# Altium schematic sheet 1_Motor_And_Power.SchDoc
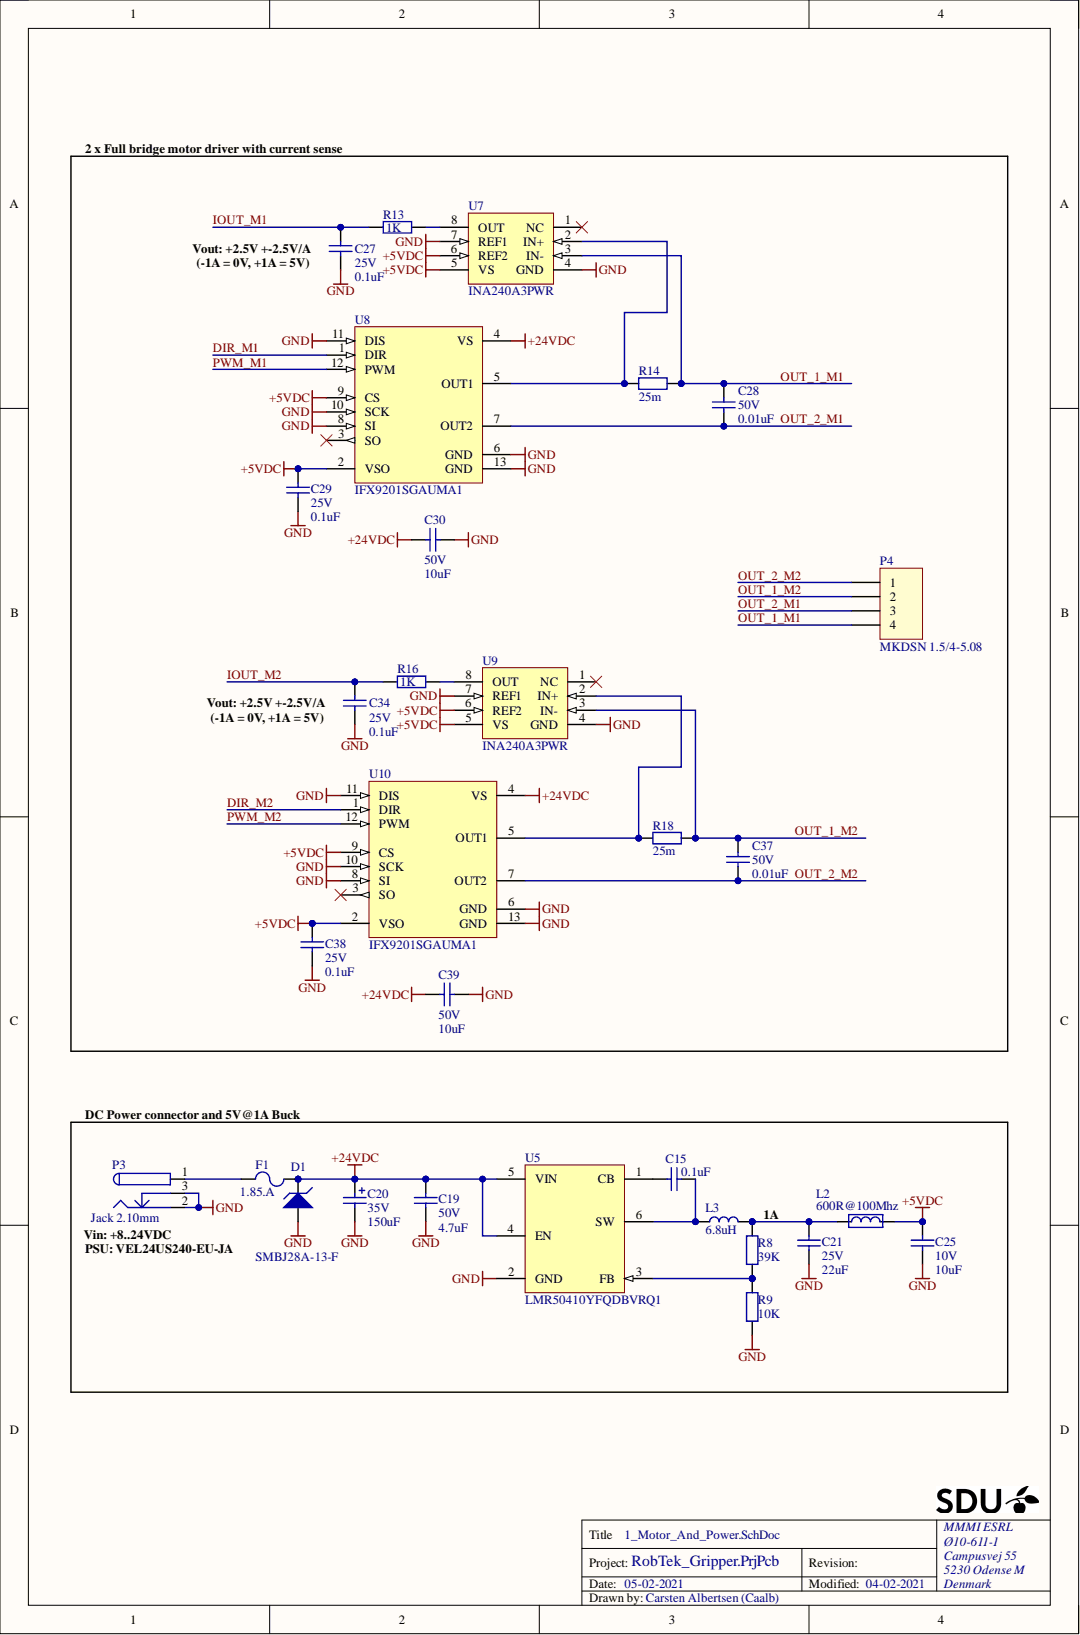
[diagram p0: 1_Motor_And_Power.SchDoc]

## Parts
C15  value 0.1uF  [0603C]  (hidden comment: 25V)
C19  50V  value 4.7uF  [1206C]
C20  value 150uF  Voltage: 35V  [ELYT SIZE F]  (hidden comment: PCR1V151MCL6GS)
C21  25V  value 22uF  [1210C_H]
C25  10V  value 10uF  [0805C]
C27  25V  value 0.1uF  [0603C]
C28  50V  value 0.01uF  [0603C]
C29  25V  value 0.1uF  [0603C]
C30  50V  value 10uF  [1210C_H]
C34  25V  value 0.1uF  [0603C]
C37  50V  value 0.01uF  [0603C]
C38  25V  value 0.1uF  [0603C]
C39  50V  value 10uF  [1210C_H]
D1  SMBJ28A-13-F  [DO-214AA]
F1  value 1.85.A  [2920F]  (hidden comment: 0ZCG0075BF2B)
L2  600R@100Mhz  [0805L]
L3  value 6.8uH  [PA4332]  (hidden comment: PA4332.682NLT)
P3  Jack 2.10mm  [694106301002]
P4  MKDSN 1.5/4-5.08  [MKDSN 1,5/4-5,08]
R8  1%  value 39K  [0603R]
R9  1%  value 10K  [0603R]
R13  1%  value 1K  [0603R]
R14  value 25m  [1206R]  (hidden comment: 1%)
R16  1%  value 1K  [0603R]
R18  value 25m  [1206R]  (hidden comment: 1%)
U5  LMR50410YFQDBVRQ1  [sot23-6]
U7  INA240A3PWR  [tssop8]
U8  IFX9201SGAUMA1  [PG-DSO-12-17]
U9  INA240A3PWR  [tssop8]
U10  IFX9201SGAUMA1  [PG-DSO-12-17]

## Nets
+5VDC: C25.2 C29.2 C38.2 L2.2 U7.5(VS) U7.6(REF2) U8.2(VSO) U8.9(CS) U9.5(VS) U9.6(REF2) U10.2(VSO) U10.9(CS)
+24VDC: C19.2 C20.1 C30.2 C39.2 D1.2 F1.2 U5.4(EN) U5.5(VIN) U8.4(VS) U10.4(VS)
GND: C19.1 C20.2 C21.1 C25.1 C27.1 C29.1 C30.1 C34.1 C38.1 C39.1 D1.1 P3.2 P3.3 R9.1 U5.2(GND) U7.4(GND) U7.7(REF1) U8.6(GND) U8.8(SI) U8.10(SCK) U8.11(DIS) U8.13(GND) U9.4(GND) U9.7(REF1) U10.6(GND) U10.8(SI) U10.10(SCK) U10.11(DIS) U10.13(GND)
DIR_M1: U8.1(DIR)
DIR_M2: U10.1(DIR)
IOUT_M1: C27.2 R13.2
IOUT_M2: C34.2 R16.2
OUT_1_M1: C28.2 P4.4 R14.2 U7.3(IN-)
OUT_1_M2: C37.2 P4.2 R18.2 U9.3(IN-)
OUT_2_M1: C28.1 P4.3 U8.7(OUT2)
OUT_2_M2: C37.1 P4.1 U10.7(OUT2)
PWM_M1: U8.12(PWM)
PWM_M2: U10.12(PWM)
NetC15_1: C15.1 L3.1 U5.6(SW)
NetC15_2: C15.2 U5.1(CB)
NetC21_2: C21.2 L2.1 L3.2 R8.2
NetF1_1: F1.1 P3.1
NetR8_1: R8.1 R9.2 U5.3(FB)
NetR13_1: R13.1 U7.8(OUT)
NetR14_1: R14.1 U7.2(IN+) U8.5(OUT1)
NetR16_1: R16.1 U9.8(OUT)
NetR18_1: R18.1 U9.2(IN+) U10.5(OUT1)

Not connected: U7 1(NC); U8 3(SO); U9 1(NC); U10 3(SO)

## Library data of the parts
C15 C27 C29 C34 C38 = Cap_0603_.1uF: Capacitor, SMD, 0603, X7R; Component Kind: Standard; Simulation: CAP; Supplier 1: RS-Components; Supplier 2: Farnell; Supplier 3: Digi-Key; Supplier 4: Mouser; Library Name: Ofte_Benyttede.SCHLIB
C19 = Cap_1206_4.7uF: 4.7µF 50V Ceramic Capacitor X7R 1206 (3216 Metric) 0.126" L x 0.063" W (3.20mm x 1.60mm); Component Kind: Standard; ComponentLink1Description: http://www.farnell.com/datasheets/415379.pdf; ComponentLink1URL: http://www.farnell.com/datasheets/415379.pdf; Simulation: CAP; Supplier 1: RS-Components; Supplier 2: Farnell; Supplier 3: Digi-Key; Supplier 4: Mouser; Supplier Part Number 3: 1276-3179-1-ND; Library Name: Ofte_Benyttede.SCHLIB
C20 = PCR1V151MCL6GS: 150µF 35V Aluminum - Polymer Capacitors Radial, Can - SMD 22mOhm 4000 Hrs @ 125°C; Component Kind: Standard; Supplier 1: RS-Components; Supplier 2: Farnell; Supplier 3: Digi-Key; Supplier 4: Mouser; Supplier Part Number 3: 493-13761-1-ND; Supplier Part Number 4: 647-PCR1V151MCL6GS; Library Name: DivLib.SCHLIB
C21 = Cap_1210_22uF: 22µF 25V Ceramic Capacitor X5R 1210 (3225 Metric) 0.126" L x 0.098" W (3.20mm x 2.50mm); Component Kind: Standard; ComponentLink1Description: http://www.yuden.co.jp/ut/product/pdf/djmk_e.pdf; ComponentLink1URL: http://www.yuden.co.jp/ut/product/pdf/djmk_e.pdf; Simulation: CAP; Supplier 1: RS-Components; Supplier 2: Farnell; Supplier 3: Digi-Key; Supplier 4: Mouser; Supplier Part Number 3: 490-3889-2-ND; Library Name: Ofte_Benyttede.SCHLIB
C25 = Cap_0805_10uF: Capacitor, SMD, 0805, X5R; Component Kind: Standard; ComponentLink1Description: http://search.murata.co.jp/Ceramy/image/img/PDF/ENG/GRM21BR61A106KE19.pdf; ComponentLink1URL: http://search.murata.co.jp/Ceramy/image/img/PDF/ENG/GRM21BR61A106KE19.pdf; Simulation: CAP; Supplier 1: RS-Components; Supplier 2: Farnell; Supplier 3: Digi-Key; Supplier 4: Mouser; Supplier Part Number 1: 106-846; Supplier Part Number 3: 490-1709-1-ND; Library Name: Ofte_Benyttede.SCHLIB
C28 C37 = Cap_0603_.01uF: Capacitor, SMD, 0603, X7R; Component Kind: Standard; Simulation: CAP; Supplier 1: RS-Components; Supplier 2: Farnell; Supplier 3: Digi-Key; Supplier 4: Mouser; Library Name: Ofte_Benyttede.SCHLIB
C30 C39 = Cap_1210_10uF: 10µF ±10% 50V Ceramic Capacitor X7R 1210 (3225 Metric); PartNumber: GRM32ER61H106KA12L; Component Kind: Standard; Simulation: CAP; Supplier 1: RS-Components; Supplier 2: Farnell; Supplier 3: Digi-Key; Supplier 4: Mouser; Supplier Part Number 3: 1276-3388-1-ND; Library Name: Ofte_Benyttede.SCHLIB
D1 = SMBJ28A-13-F: 45.4V Clamp 13.2A Ipp Tvs Diode Surface Mount SMB; Component Kind: Standard; Supplier 1: RS-Components; Supplier 2: Farnell; Supplier 3: Digi-Key; Supplier 4: Mouser; Supplier Part Number 3: SMBJ28A-FDICT-ND; Library Name: Diodes_egne.SchLib
F1 = 0ZCG0075BF2B: Polymeric PTC Resettable Fuse 33V 1.85A Ih Surface Mount 2920 (7351 Metric), Concave; Component Kind: Standard; ComponentLink1Description: http://www.belfuse.com/pdfs/0ZCG.pdf; ComponentLink1URL: http://www.belfuse.com/pdfs/0ZCG.pdf; Supplier 1: RS-Components; Supplier 2: Farnell; Supplier 3: Digi-Key; Supplier 4: Mouser; Supplier Part Number 3: 507-1747-1-ND; Library Name: DivLib.SCHLIB
L2 = Ferrite_0805: Ferrite bead 1.5A, 90mOhm, 330R@100Mhz; Component Kind: Standard; Supplier 1: RS-Components; Supplier 2: Farnell; Supplier 3: Digi-Key; Supplier 4: Mouser; Supplier Part Number 3: 490-5988-1-ND; Value: 330R@100Mhz; Library Name: Ofte_Benyttede.SCHLIB
L3 = PA4332.682NLT: 6.8µH Shielded Wirewound Inductor 2.4A 156mOhm Max Nonstandard; Component Kind: Standard; ComponentLink1Description: http://www.toko.co.jp/products-murata/pdf/inductors/m_dem8045c.pdf; ComponentLink1URL: http://www.toko.co.jp/products-murata/pdf/inductors/m_dem8045c.pdf; Supplier 1: RS-Components; Supplier 2: Farnell; Supplier 3: Digi-Key; Supplier 4: Mouser; Supplier Part Number 3: 553-3293-1-ND; Library Name: DivLib.SCHLIB
P3 = 694106301002: Power Barrel Connector Jack 2.10mm ID (0.083"), 5.50mm OD (0.217") Through Hole, Right Angle; Component Kind: Standard; Supplier 1: RS-Components; Supplier 2: Farnell; Supplier 3: Digi-Key; Supplier 4: Mouser; Supplier Part Number 3: 732-5930-ND; Library Name: Connector_Div_egne.SchLib
P4 = MKDSN 1.5/4-5.08: 4-Pin, 5.08mm, 250V, 16A; Component Kind: Standard; ComponentLink1Description: http://media.digikey.com/pdf/Data%20Sheets/Phoenix%20Contact%20PDFs/1729186.pdf; ComponentLink1URL: http://media.digikey.com/pdf/Data%20Sheets/Phoenix%20Contact%20PDFs/1729186.pdf; Supplier 1: RS-Components; Supplier 2: Farnell; Supplier 3: Digi-Key; Supplier 4: Mouser; Supplier Part Number 1: 290-1264; Supplier Part Number 3: 277-1249-ND; Library Name: Phonix_egne.SchLib
R8 R9 R13 R16 = Res_0603: Resistor, SMD, 0603, 0.063W; Component Kind: Standard; Simulation: RESISTOR; Supplier 1: RS-Components; Supplier 2: Farnell; Supplier 3: Digi-Key; Supplier 4: Mouser; Library Name: Ofte_Benyttede.SCHLIB
R14 R18 = Res_1206: 25 mOhms ±1% 0.5W, 1/2W Chip Resistor 1206 (3216 Metric) Automotive AEC-Q200, Current Sense Thick Film; Component Kind: Standard; Simulation: RESISTOR; Supplier 1: RS-Components; Supplier 2: Farnell; Supplier 3: Digi-Key; Supplier 4: Mouser; Supplier Part Number 3: 2019-UR73VD2BTTD25L0FCT-ND; Library Name: Ofte_Benyttede.SCHLIB
U5 = LMR50410YFQDBVRQ1: Buck Switching Regulator IC Positive Adjustable 1V 1 Output 1A SOT-23-6; Component Kind: Standard; ComponentLink1Description: http://www.ti.com/lit/ds/symlink/lmr16006.pdf; ComponentLink1URL: http://www.ti.com/lit/ds/symlink/lmr16006.pdf; Supplier 1: RS-Components; Supplier 2: Farnell; Supplier 3: Digi-Key; Supplier 4: Mouser; Supplier Part Number 3: 296-LMR50410YFQDBVRQ1CT-ND; Library Name: Texas_inst_egne.SchLib
U7 U9 = INA240A3PWR: INA240 High- and Low-Side, Bidirectional, Zero-Drift, Current-Sense Amplifier, G: 100V/V; Component Kind: Standard; Supplier 1: RS-Components; Supplier 2: Farnell; Supplier 3: Digi-Key; Supplier 4: Mouser; Supplier Part Number 3: 296-45090-1-ND; Library Name: Texas_inst_egne.SchLib
U8 U10 = IFX9201SGAUMA1: Half Bridge (2) Driver DC Motors, General Purpose Power MOSFET PG-DSO-12-17; Component Kind: Standard; Supplier 1: RS-Components; Supplier 2: Farnell; Supplier 3: Digi-Key; Supplier 4: Mouser; Supplier Part Number 3: IFX9201SGAUMA1CT-ND; Library Name: Infinion_egne.SchLib
